# Altium schematic sheet SD_CARD_REV2.SchDoc(SD_CARD_REV2)
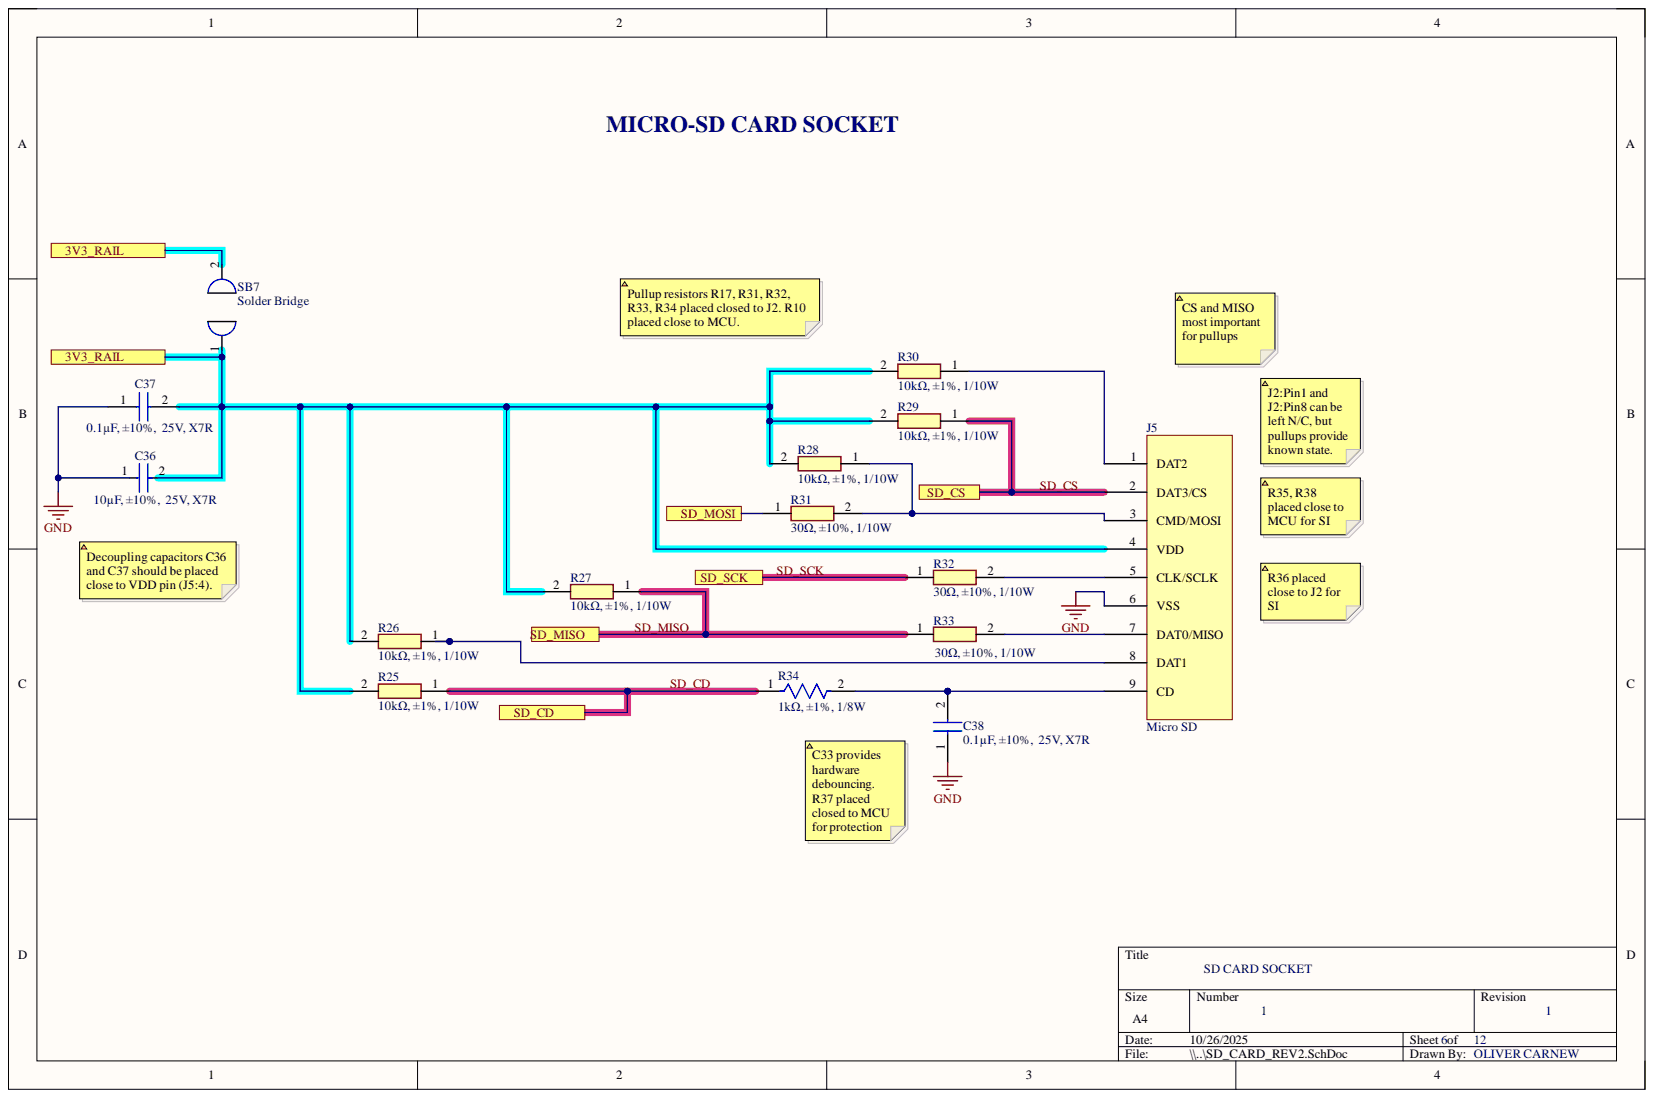
[diagram p0: SD_CARD_REV2.SchDoc]

## Parts
C36  10µF, ±10%,  25V, X7R  [FP-CL21B106KOQNNNE-MFG]
C37  0.1µF, ±10%,  25V, X7R  [CAPC1005X55N]
C38  0.1µF, ±10%,  25V, X7R  [CAPC1005X55N]
J5  Micro SD  [SD CARD]
R25  10kΩ, ±1%, 1/10W  [RESC2012X60N]
R26  10kΩ, ±1%, 1/10W  [RESC2012X60N]
R27  10kΩ, ±1%, 1/10W  [RESC2012X60N]
R28  10kΩ, ±1%, 1/10W  [RESC2012X60N]
R29  10kΩ, ±1%, 1/10W  [RESC2012X60N]
R30  10kΩ, ±1%, 1/10W  [RESC2012X60N]
R31  30Ω, ±10%, 1/10W  [RESC1608X55N]
R32  30Ω, ±10%, 1/10W  [RESC1608X55N]
R33  30Ω, ±10%, 1/10W  [RESC1608X55N]
R34  1kΩ, ±1%, 1/8W  [FP-RNCP0603-IPC_A]
SB7  Solder Bridge  [SOLDER BRIDGE OPEN SMALL]

## Ports
3V3_RAIL, SD_CD, SD_CS, SD_MISO, SD_MOSI, SD_SCK

## Nets
GND: C36.1 C37.1 C38.1 J5.6(VSS)
3V3_RAIL: C36.2 C37.2 J5.4(VDD) R25.2 R26.2 R27.2 R28.2 R29.2 R30.2 SB7.1 SB7.2 ; port 3V3_RAIL
SD_CD: R25.1 R34.1 ; port SD_CD
SD_CS: J5.2(DAT3/CS) R29.1 ; port SD_CS
SD_MISO: R27.1 R33.1 ; port SD_MISO
SD_MOSI: R31.1 ; port SD_MOSI
SD_SCK: R32.1 ; port SD_SCK
NetC38_2: C38.2 J5.9(CD) R34.2
NetJ5_1: J5.1(DAT2) R30.1
NetJ5_3: J5.3(CMD/MOSI) R28.1 R31.2
NetJ5_5: J5.5(CLK/SCLK) R32.2
NetJ5_7: J5.7(DAT0/MISO) R33.2
NetJ5_8: J5.8(DAT1) R26.1

## Library data of the parts
C36 = CMP-2000-06106-2: CAP CER 10UF 16V X7R 0805 CL21B106KOQNNNE; Manufacturer: Samsung Electro-Mechanics America, Inc; Material: Ceramic; Temperature Coefficient: 15%; Automotive: No; Capacitance: 10uF; Category: Cap; Component Kind: Standard; ComponentLink2Description: Datasheet; ComponentLink2URL: http://www.samsungsem.com/servlet/FileDownload?path=productsearch&sname=CL21B106KOQNNNE.pdf&oname=CL21B106KOQNNNE.pdf; Device Class L1: Passive Components; Device Class L2: Capacitors; Device Class L3: Ceramic Capacitors; Digikey Description: CAP CER 10UF 16V X7R 0805; Height: 1.4mm; Ipc Land Pattern Name: CAPC200125X125; Lead Free: Yes; Library Name: Altium Content Vault; Manufacturer Part Number: CL21B106KOQNNNE; Octopart: https://octopart.com/cl21b106koqnnne-samsung-19832289; Package: CL21B106KOQNNNE; RoHS: TRUE; Temperature: -55°C to +125°C; Temperature Characteristic: X7R; Temperature Range High: +125°C; Temperature Range Low: -55°C; Tolerance: 10%; Value: 10uF; Voltage Rating: 16V
C37 C38 = CL05B104KA5NNNC: Capacitor CAP CER 0.1UF 25V X7R 0402 CL05B104KA5NNNC; Manufacturer_Name: SAMSUNG; Arrow Part Number: CL05B104KA5NNNC; Arrow Price/Stock: https://www.arrow.com/en/products/cl05b104ka5nnnc/samsung-electro-mechanics?region=nac; Component Kind: Standard; Datasheet Link: https://datasheet.datasheetarchive.com/originals/distributors/DKDS-19/372989.pdf; Height: 0.55mm; Manufacturer_Part_Number: CL05B104KA5NNNC; Mouser Part Number: 187-CL05B104KA5NNNC; Mouser Price/Stock: https://www.mouser.co.uk/ProductDetail/Samsung-Electro-Mechanics/CL05B104KA5NNNC?qs=Efv9o2T6U88DLyyMSzjXSQ%3D%3D; Parameter 1: Capacitor CAP CER 0.1UF 25V X7R 0402 CL05B104KA5NNNC
J5 = SD_CARD_MICRO: ETSG; Component Kind: Standard; Library Name: DANCE_LIB_REV2.SchLib
R25-R30 = RC0805JR-0710KL: Resistor RES 10K OHM 5% 1/8W 0805  RC0805JR-0710KL; Manufacturer_Name: YAGEO; Arrow Part Number: RC0805JR-0710KL; Arrow Price/Stock: https://www.arrow.com/en/products/rc0805jr-0710kl/yageo?region=nac; Component Kind: Standard; Datasheet Link: https://componentsearchengine.com/Datasheets/2/RC0805JR-0710KL.pdf; Height: 0.6mm; Manufacturer_Part_Number: RC0805JR-0710KL; Mouser Part Number: 603-RC0805JR-0710KL; Mouser Price/Stock: https://www.mouser.co.uk/ProductDetail/YAGEO/RC0805JR-0710KL?qs=ZFGQJeMQzF5q%2Fp77vM%2FrVQ%3D%3D
R31-R33 = ERJPA3F30R0V: Resistor ERJPA3F30R0V 30 Ohms ±1% 0.333W, 1/3W Chip Resistor 0603 (1608 Metric) Automotive AEC-Q200, Pulse Withstanding Thick Film; Manufacturer_Name: Panasonic; Arrow Part Number: ERJPA3F30R0V; Arrow Price/Stock: https://www.arrow.com/en/products/erj-pa3f30r0v/panasonic; Component Kind: Standard; Datasheet Link: https://industrial.panasonic.com/cdbs/www-data/pdf/RDA0000/AOA0000C243.pdf; Height: 0.55mm; Manufacturer_Part_Number: ERJPA3F30R0V; Parameter 1: Resistor ERJPA3F30R0V 30 Ohms ±1% 0.333W, 1/3W Chip Resistor 0603 (1608 Metric) Automotive AEC-Q200, Pulse Withstanding Thick Film
R34 = CMP-26527-006179-1: 1kΩ ±1% 0.125W 0603 High Power Anti-Sulfur Thin Film Chip Resistor RNCP0603FTD1K00; Max Power Dissipation: 125mW; Resistance: 1kR; Temperature Coefficient: 100ppm/°C; Case Code (Imperial): 0603; Case Code (Metric): 1608; Case/Package: 0603; Component Kind: Standard; Features: Moisture Resistant; Height: 0.02inch; Length: 0.059inch; Library Name: Altium Content Vault; Max Operating Temperature: 155°C; Min Operating Temperature: -55°C; Mount: Surface Mount; Number of Terminations: 2; Packaging: Tape and Reel; Resistor Type: Thin Film; RoHS Compliant: Yes; Series: RNCP; Tolerance: 1%; Width: 0.031inch
SB7 = SOLDER_BRIDGE_TDROP: Tension Fill Solder Bridge; Component Kind: Standard; Library Name: DANCE_LIB.SchLib
